# Altium schematic sheet H bridge circuit.SchDoc
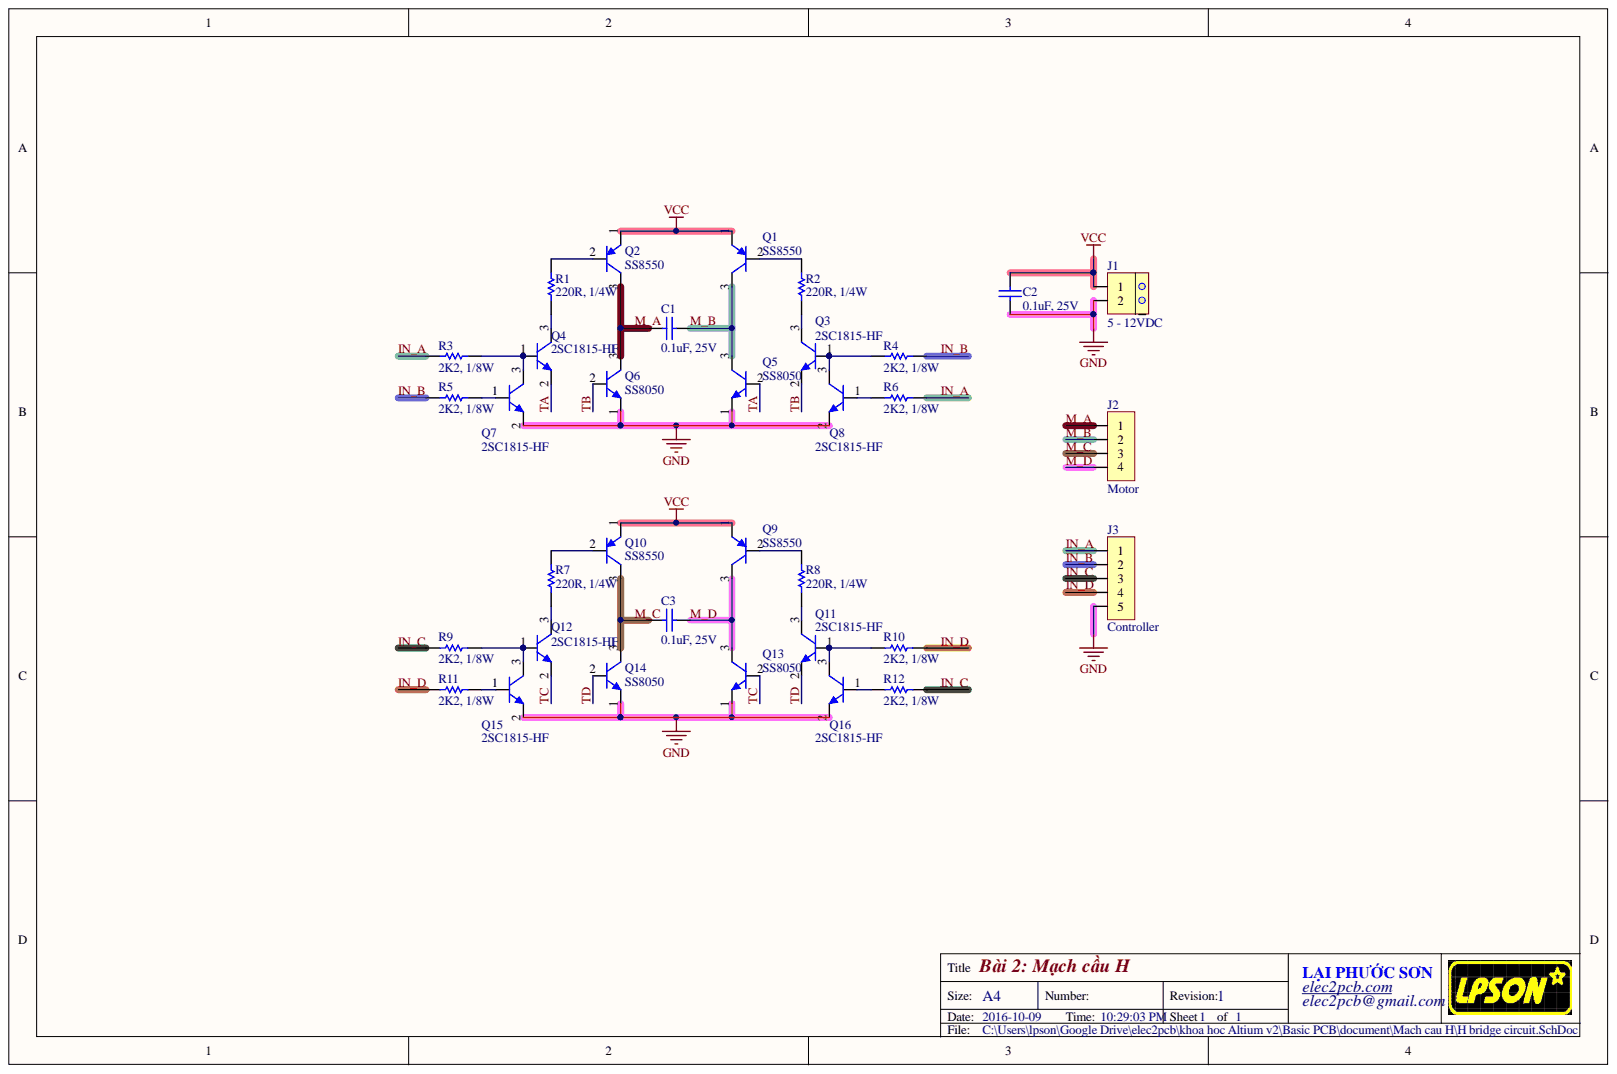
[diagram p0: H bridge circuit.SchDoc]

## Parts
C1  value 0.1uF, 25V  [C0_1uF]  (hidden comment: 5WF104MABAA)
C2  value 0.1uF, 25V  [C0_1uF]  (hidden comment: 5WF104MABAA)
C3  value 0.1uF, 25V  [C0_1uF]  (hidden comment: 5WF104MABAA)
J1  value 5 - 12VDC  [CON2]  (hidden comment: OSTTC-02-2162)
J2  value Motor  [Header_4]  (hidden comment: 2012-254-1x04-SG)
J3  value Controller  [Header_5]  (hidden comment: 2012-254-1x05-SG)
Q1  SS8550  [SS8550]
Q2  SS8550  [SS8550]
Q3  2SC1815-HF  [2SC1815-HF]
Q4  2SC1815-HF  [2SC1815-HF]
Q5  SS8050  [SS8050]
Q6  SS8050  [SS8050]
Q7  2SC1815-HF  [2SC1815-HF]
Q8  2SC1815-HF  [2SC1815-HF]
Q9  SS8550  [SS8550]
Q10  SS8550  [SS8550]
Q11  2SC1815-HF  [2SC1815-HF]
Q12  2SC1815-HF  [2SC1815-HF]
Q13  SS8050  [SS8050]
Q14  SS8050  [SS8050]
Q15  2SC1815-HF  [2SC1815-HF]
Q16  2SC1815-HF  [2SC1815-HF]
R1  value 220R, 1/4W  [Res AXIAL-0.3]  (hidden comment: CF1/4W220RJ25)
R2  value 220R, 1/4W  [Res AXIAL-0.3]  (hidden comment: CF1/4W220RJ25)
R3  value 2K2, 1/8W  [RESC2012L]  (hidden comment: CR05JL7-2K2)
R4  value 2K2, 1/8W  [RESC2012L]  (hidden comment: CR05JL7-2K2)
R5  value 2K2, 1/8W  [RESC2012L]  (hidden comment: CR05JL7-2K2)
R6  value 2K2, 1/8W  [RESC2012L]  (hidden comment: CR05JL7-2K2)
R7  value 220R, 1/4W  [Res AXIAL-0.3]  (hidden comment: CF1/4W220RJ25)
R8  value 220R, 1/4W  [Res AXIAL-0.3]  (hidden comment: CF1/4W220RJ25)
R9  value 2K2, 1/8W  [RESC2012L]  (hidden comment: CR05JL7-2K2)
R10  value 2K2, 1/8W  [RESC2012L]  (hidden comment: CR05JL7-2K2)
R11  value 2K2, 1/8W  [RESC2012L]  (hidden comment: CR05JL7-2K2)
R12  value 2K2, 1/8W  [RESC2012L]  (hidden comment: CR05JL7-2K2)

## Nets
GND: C2.1 J1.2 J3.5 Q5.1 Q6.1 Q7.2 Q8.2 Q13.1 Q14.1 Q15.2 Q16.2
VCC: C2.2 J1.1 Q1.1 Q2.1 Q9.1 Q10.1
IN_A: J3.1 R3.1 R6.2
IN_B: J3.2 R4.2 R5.1
IN_C: J3.3 R9.1 R12.2
IN_D: J3.4 R10.2 R11.1
M_A: C1.1 J2.1 Q2.3 Q6.3
M_B: C1.2 J2.2 Q1.3 Q5.3
M_C: C3.1 J2.3 Q10.3 Q14.3
M_D: C3.2 J2.4 Q9.3 Q13.3
TA: Q4.2 Q5.2
TB: Q3.2 Q6.2
TC: Q12.2 Q13.2
TD: Q11.2 Q14.2
NetQ1_2: Q1.2 R2.2
NetQ2_2: Q2.2 R1.2
NetQ3_1: Q3.1 Q8.3 R4.1
NetQ3_3: Q3.3 R2.1
NetQ4_1: Q4.1 Q7.3 R3.2
NetQ4_3: Q4.3 R1.1
NetQ7_1: Q7.1 R5.2
NetQ8_1: Q8.1 R6.1
NetQ9_2: Q9.2 R8.2
NetQ10_2: Q10.2 R7.2
NetQ11_1: Q11.1 Q16.3 R10.1
NetQ11_3: Q11.3 R8.1
NetQ12_1: Q12.1 Q15.3 R9.2
NetQ12_3: Q12.3 R7.1
NetQ15_1: Q15.1 R11.2
NetQ16_1: Q16.1 R12.1

## Library data of the parts
C1 C3 = 5WF104MABAA: CAP Y5P CAPNTO 0.1uF 25V 20%; Component Kind: Standard; ComponentLink1Description: Datasheet; Unit Price: 0.003; ComponentLink1URL: D:\Dropbox\Datasheets\Passive Components\Capacitors\AVX Disc Ceramic Capacitors.pdf; Library Name: Sau_Capacitors.SchLib
C2 = 5WF104MABAA: CAP Y5P CAPNTO 0.1uF 25V 20%; Value: 0.1uF, 25V; Component Kind: Standard; ComponentLink1Description: Datasheet; Unit Price: 0.003; ComponentLink1URL: D:\Dropbox\Datasheets\Passive Components\Capacitors\AVX Disc Ceramic Capacitors.pdf; Library Name: Sau_Capacitors.SchLib
J1 = OSTTC-02-2162: Terminal Block 2 Poles 5.08mm Blue; Component Kind: Standard; ComponentLink1Description: Datasheet; Unit Price: 0.076; ComponentLink1URL: D:\Dropbox\Datasheets\Passive Components\Connectors\OSTTC-XX-2162.pdf; Library Name: Sau_Connectors.SchLib
J2 = 2012-254-1x04-SG: Male Header 04 Pin 2.54mm Straight; Component Kind: Standard; ComponentLink1Description: Datasheet; Unit Price: 0.032; ComponentLink1URL: D:\Dropbox\Datasheets\Passive Components\Connectors\2012-254-1xXX-SG.pdf; Library Name: Sau_Connectors.SchLib
J3 = 2012-254-1x05-SG: Male Header 05 Pin 2.54mm Straight; Component Kind: Standard; ComponentLink1Description: Datasheet; Unit Price: 0.032; ComponentLink1URL: D:\Dropbox\Datasheets\Passive Components\Connectors\2012-254-1xXX-SG.pdf; Library Name: Sau_Connectors.SchLib
Q1 Q2 Q9 Q10 = SS8550: Trans PNP -25V -1.5A TO-92; Component Kind: Standard; ComponentLink1Description: Datasheet; Unit Price: 0.0476; ComponentLink1URL: D:\Dropbox\Datasheets\Transistors\N Channel Bipolar\SS8550.pdf; Library Name: Sau_Transistors.SchLib
Q3 Q4 Q7 Q8 Q11 Q12 Q15 Q16 = 2SC1815-HF: Trans NPN 50V 150mA SOT-23; Component Kind: Standard; ComponentLink1Description: Datasheet; Unit Price: 0.0286; ComponentLink1URL: D:\Dropbox\Datasheets\Transistors\P Channel Bipolar\2SC1815-HF.pdf; Library Name: Sau_Transistors.SchLib
Q5 Q6 Q13 Q14 = SS8050: Trans NPN 25V 1.5A TO-92; Component Kind: Standard; ComponentLink1Description: Datasheet; Unit Price: 0.0476; ComponentLink1URL: D:\Dropbox\Datasheets\Transistors\P Channel Bipolar\SS8050.pdf; Library Name: Sau_Transistors.SchLib
R1 R7 = CF1/4W220RJ25: RES CF AXIAL-0.3 5% 1/4W 220R; Value: 220R, 1/4W; Component Kind: Standard; ComponentLink1Description: Datasheet; Unit Price: 0.001; ComponentLink1URL: D:\Dropbox\Datasheets\Passive Components\Resistors\Carbon Film Resistor.pdf; Library Name: Sau_Resistors.SchLib
R2 R8 = CF1/4W220RJ25: RES CF AXIAL-0.3 5% 1/4W 220R; Component Kind: Standard; ComponentLink1Description: Datasheet; Unit Price: 0.001; ComponentLink1URL: D:\Dropbox\Datasheets\Passive Components\Resistors\Carbon Film Resistor.pdf; Library Name: Sau_Resistors.SchLib
R3-R6 R9-R12 = CR05JL7-2K2: RES CR 0805 5% 1/8W 2K2; Component Kind: Standard; ComponentLink1Description: Datasheet; Unit Price: 0.000802; ComponentLink1URL: D:\Dropbox\Datasheets\Passive Components\Resistors\Thick Film Chip Resistor CR.pdf; Library Name: Sau_Resistors.SchLib
